# Altium schematic sheet AnalogEnvelopePath.SchDoc(AnalogEnvelopePath)
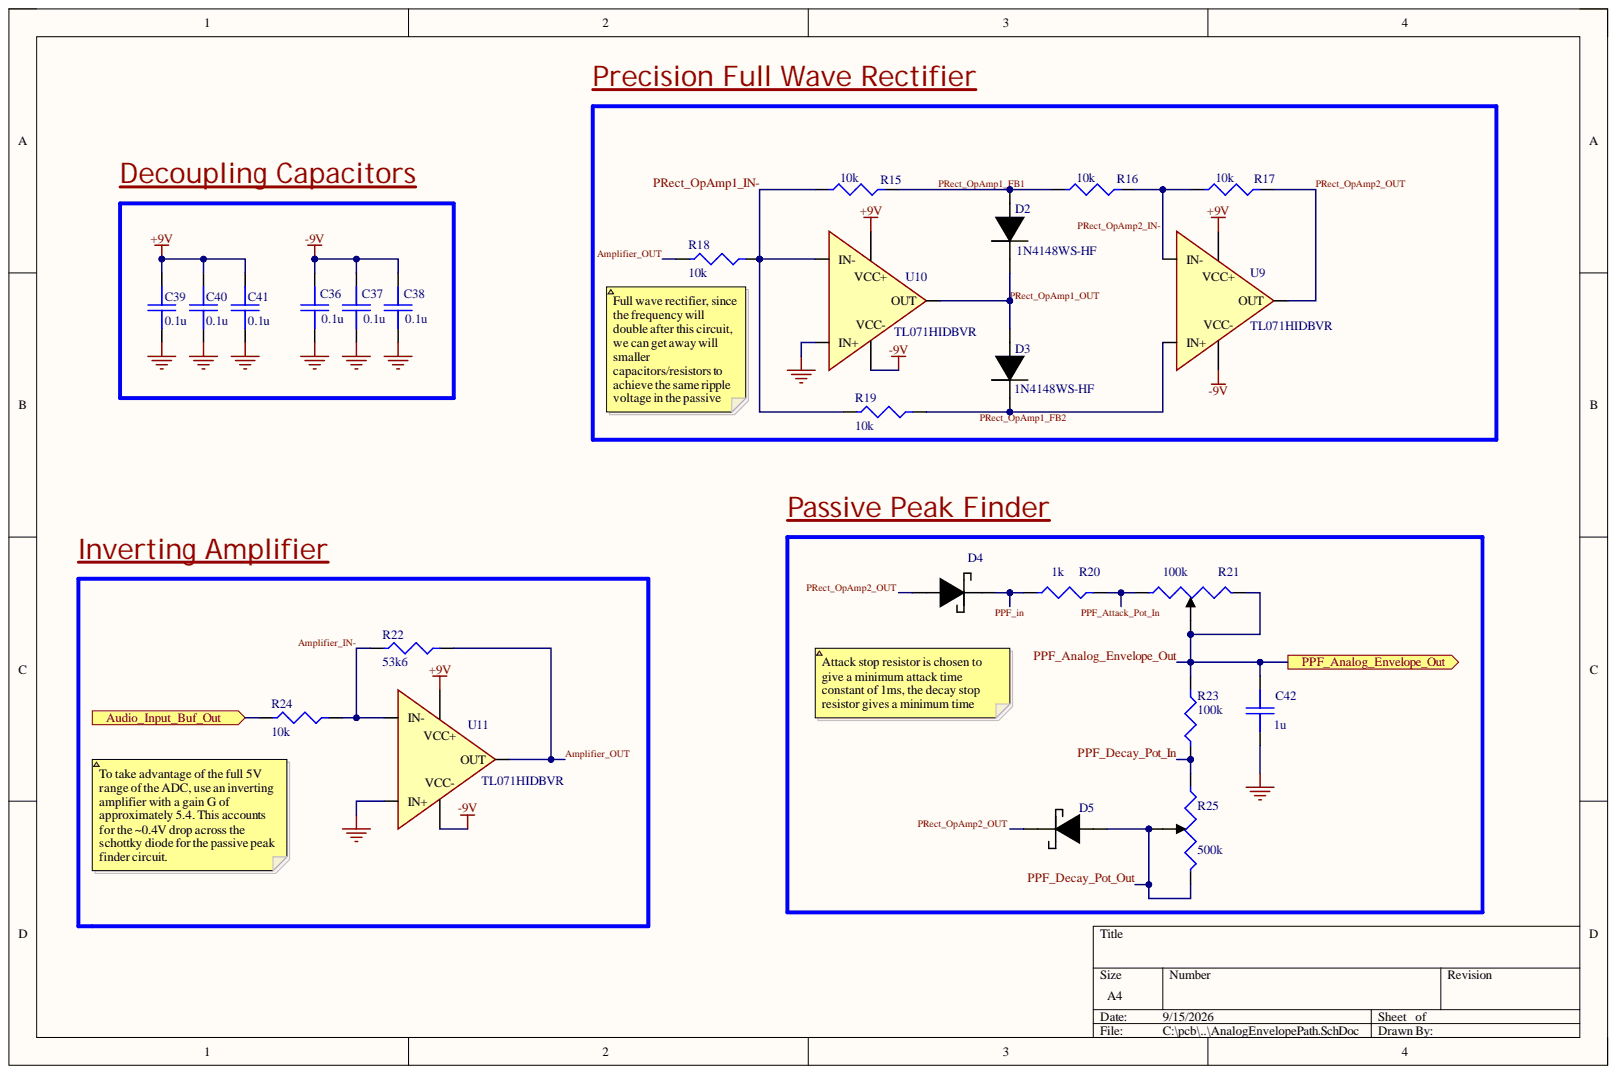
[diagram p0: AnalogEnvelopePath.SchDoc]

## Parts
C36  0.1u  [C0603]
C37  0.1u  [C0603]
C38  0.1u  [C0603]
C39  0.1u  [C0603]
C40  0.1u  [C0603]
C41  0.1u  [C0603]
C42  1u  [B32529D1105J289]
D2  1N4148WS-HF  [1N4148WS-HF]
D3  1N4148WS-HF  [1N4148WS-HF]
D4  CMOD6263 TR PBFREE  [CMOD6263]  (value not drawn)
D5  CMOD6263 TR PBFREE  [CMOD6263]  (value not drawn)
R15  10k  [R0603]
R16  10k  [R0603]
R17  10k  [R0603]
R18  10k  [R0603]
R19  10k  [R0603]
R20  1k  [R0603]
R21  100k  [RD901F-40-15R1-B100K-00D]
R22  53k6  [R0603]
R23  100k  [R0603]
R24  10k  [R0603]
R25  500k  [RD901F-40-15R1-B500K]
U9  TL071HIDBVR  [TL071HIDBVR]
U10  TL071HIDBVR  [TL071HIDBVR]
U11  TL071HIDBVR  [TL071HIDBVR]

## Ports
Audio_Input_Buf_Out, PPF_Analog_Envelope_Out

## Nets
+9V: C39.1 C40.1 C41.1 U9.5 U10.5 U11.5
-9V: C36.2 C37.2 C38.2 U9.2 U10.2 U11.2
GND: C36.1 C37.1 C38.1 C39.2 C40.2 C41.2 C42.1 U10.3(IN+) U11.3(IN+)
Amplifier_IN-: R22.1 R24.2 U11.4(IN-)
Amplifier_OUT: R18.1 R22.2 U11.1(OUT)
Audio_Input_Buf_Out: R24.1 ; port Audio_Input_Buf_Out
PPF_Analog_Envelope_Out: C42.2 R21.2 R21.3 R23.2 ; port PPF_Analog_Envelope_Out
PPF_Attack_Pot_In: R20.2 R21.1
PPF_Decay_Pot_In: R23.1 R25.1
PPF_Decay_Pot_Out: D5.2 R25.2 R25.3
PPF_in: D4.1 R20.1
PRect_OpAmp1_FB1: D2.2 R15.2 R16.1
PRect_OpAmp1_FB2: D3.1 R19.2 U9.3(IN+)
PRect_OpAmp1_IN-: R15.1 R18.2 R19.1 U10.4(IN-)
PRect_OpAmp1_OUT: D2.1 D3.2 U10.1(OUT)
PRect_OpAmp2_IN-: R16.2 R17.1 U9.4(IN-)
PRect_OpAmp2_OUT: D4.2 D5.1 R17.2 U9.1(OUT)

## Library data of the parts
C36-C41 = C0603C104K5RACAUTO: Multilayer Ceramic Capacitors MLCC - SMD/SMT 50V 0.1uF X7R 0603 10% AEC-Q200; Manufacturer: Yageo Group; Dissipation Factor: 2.5%; Temperature Coefficient: 30ppm/°C; Value: 100nF; Case Code (Imperial): 0603; Case Code (Metric): 1608; Case/Package: 0603; Category: Ceramic Capacitors; China RoHS: Compliant; Component Kind: Standard; ComponentLink1Description: https://datasheet.octopart.com:443/C0603C104K5RACAUTO-KEMET-datasheet-178087915.pdf?src-supplier=Farnell; ComponentLink1URL: https://datasheet.octopart.com:443/C0603C104K5RACAUTO-KEMET-datasheet-178087915.pdf?src-supplier=Farnell; ComponentLink2Description: https://datasheet.octopart.com:443/C0603C104K5RACAUTO-KEMET-datasheet-140480346.pdf?src-supplier=Farnell; ComponentLink2URL: https://datasheet.octopart.com:443/C0603C104K5RACAUTO-KEMET-datasheet-140480346.pdf?src-supplier=Farnell; ComponentLink3Description: https://datasheet.octopart.com:443/C0603C104K5RACAUTO-KEMET-datasheet-138896278.pdf?src-supplier=Farnell; ComponentLink3URL: https://datasheet.octopart.com:443/C0603C104K5RACAUTO-KEMET-datasheet-138896278.pdf?src-supplier=Farnell; ComponentLink4Description: https://datasheet.octopart.com:443/C0603C104K5RACAUTO-KEMET-datasheet-101575653.pdf?src-supplier=Farnell; ComponentLink4URL: https://datasheet.octopart.com:443/C0603C104K5RACAUTO-KEMET-datasheet-101575653.pdf?src-supplier=Farnell; ComponentLink5Description: https://datasheet.octopart.com:443/C0603C104K5RACAUTO-KEMET-datasheet-169773475.pdf?src-supplier=Farnell; ComponentLink5URL: https://datasheet.octopart.com:443/C0603C104K5RACAUTO-KEMET-datasheet-169773475.pdf?src-supplier=Farnell; ComponentLink6Description: https://datasheet.octopart.com:443/C0603C104K5RAC-AUTO-Kemet-datasheet-11517047.pdf?src-supplier=Farnell; ComponentLink6URL: https://datasheet.octopart.com:443/C0603C104K5RAC-AUTO-Kemet-datasheet-11517047.pdf?src-supplier=Farnell; ComponentLink7Description: https://datasheet.octopart.com:443/C0603C104K5RACAUTO-KEMET-datasheet-137357764.pdf?src-supplier=Upverter; ComponentLink7URL: https://datasheet.octopart.com:443/C0603C104K5RACAUTO-KEMET-datasheet-137357764.pdf?src-supplier=Upverter; ComponentLink8Description: https://datasheet.octopart.com:443/C0603C104K5RACAUTO-Kemet-datasheet-17277841.pdf?src-supplier=Newark; ComponentLink8URL: https://datasheet.octopart.com:443/C0603C104K5RACAUTO-Kemet-datasheet-17277841.pdf?src-supplier=Newark; ComponentLink9Description: https://datasheet.octopart.com:443/C0603C104K5RAC-AUTO-Kemet-datasheet-10850653.pdf?src-supplier=Newark; ComponentLink9URL: https://datasheet.octopart.com:443/C0603C104K5RAC-AUTO-Kemet-datasheet-10850653.pdf?src-supplier=Newark; ComponentLink10Description: https://datasheet.octopart.com:443/C0603C104K5RACAUTO-KEMET-datasheet-176289396.pdf?src-supplier=JRH+Electronics; ComponentLink10URL: https://datasheet.octopart.com:443/C0603C104K5RACAUTO-KEMET-datasheet-176289396.pdf?src-supplier=JRH+Electronics; ComponentLink11Description: https://datasheet.octopart.com:443/C0603C104K5RACAUTO-KEMET-datasheet-153410967.pdf?src-supplier=Electro+Sonic; ComponentLink11URL: https://datasheet.octopart.com:443/C0603C104K5RACAUTO-KEMET-datasheet-153410967.pdf?src-supplier=Electro+Sonic; ComponentLink12Description: https://datasheet.octopart.com:443/C0603C104K5RACAUTO-KEMET-datasheet-142166320.pdf?src-supplier=Electro+Sonic; ComponentLink12URL: https://datasheet.octopart.com:443/C0603C104K5RACAUTO-KEMET-datasheet-142166320.pdf?src-supplier=Electro+Sonic; ComponentLink13Description: https://datasheet.octopart.com:443/C0603C104K5RACAUTO-Kemet-datasheet-66076775.pdf?src-supplier=element14+APAC; ComponentLink13URL: https://datasheet.octopart.com:443/C0603C104K5RACAUTO-Kemet-datasheet-66076775.pdf?src-supplier=element14+APAC; ComponentLink14Description: https://datasheet.octopart.com:443/C0603C104K5RACAUTO-Kemet-datasheet-66204749.pdf?src-supplier=element14+APAC; ComponentLink14URL: https://datasheet.octopart.com:443/C0603C104K5RACAUTO-Kemet-datasheet-66204749.pdf?src-supplier=element14+APAC; ComponentLink15Description: https://datasheet.octopart.com:443/C0603C104K5RACAUTO-Kemet-datasheet-66534724.pdf?src-supplier=element14+APAC; ComponentLink15URL: https://datasheet.octopart.com:443/C0603C104K5RACAUTO-Kemet-datasheet-66534724.pdf?src-supplier=element14+APAC; ComponentLink16Description: https://datasheet.octopart.com:443/C0603C104K5RACAUTO-KEMET-datasheet-83968784.pdf?src-supplier=TME; ComponentLink16URL: https://datasheet.octopart.com:443/C0603C104K5RACAUTO-KEMET-datasheet-83968784.pdf?src-supplier=TME; ComponentLink17Description: https://datasheet.octopart.com:443/C0603C104K5RACAUTO-KEMET-datasheet-83186888.pdf?src-supplier=TME; ComponentLink17URL: https://datasheet.octopart.com:443/C0603C104K5RACAUTO-KEMET-datasheet-83186888.pdf?src-supplier=TME; ComponentLink18Description: https://datasheet.octopart.com/C0603C104K5RACAUTO-KEMET-datasheet-84169754.pdf; ComponentLink18URL: https://datasheet.octopart.com/C0603C104K5RACAUTO-KEMET-datasheet-84169754.pdf; ComponentLink19Description: https://datasheet.octopart.com:443/C0603C104K5RACAUTO-KEMET-datasheet-189767472.pdf?src-supplier=ODG+(Origin+Data+Global); ComponentLink19URL: https://datasheet.octopart.com:443/C0603C104K5RACAUTO-KEMET-datasheet-189767472.pdf?src-supplier=ODG+(Origin+Data+Global); ComponentLink20Description: http://sigma.octopart.com:80/conflict_mineral_statement/Yageo-company-3.pdf?src-supplier=Compliance+Statements; ComponentLink20URL: http://sigma.octopart.com:80/conflict_mineral_statement/Yageo-company-3.pdf?src-supplier=Compliance+Statements; ComponentLink21Description: http://sigma.octopart.com:80/rohs_statement/Yageo-company-2.pdf?src-supplier=Compliance+Statements; ComponentLink21URL: http://sigma.octopart.com:80/rohs_statement/Yageo-company-2.pdf?src-supplier=Compliance+Statements; Contact Plating: Tin; Country of Origin: Mexico; Depth: 800µm; Dielectric: X7R; Dielectric Material: Ceramic; Export Control Classification Number (ECCN) Code: EAR99; Harmonized Tariff Schedule (HTS) Code: 8532.24.00.20; Height: 800µm; Introduction Date: 2011-07-27; Length: 1.6mm; Lifecycle Status: Production; Manufacturer Part Number: C0603C104K5RACAUTO; Material: Ceramic; Max Operating Temperature: 125°C; Min Operating Temperature: -55°C; Mounting Technology: Surface Mount; Number of Terminals: 2; Packaging: Cut Tape; Pricing: 1=0.15, 10=0.083, 50=0.0574, 100=0.0497, 500=0.0367 (USD); Radiation Hardening: No; Ratings: AEC-Q200; REACH SVHC: Yes; RoHS: Compliant; Schedule B: 8532240020, 8532240020|8532240020, 8532240020|8532240020|8532240020, 8532240020|8532240020|8532240020|8532240020; Stock: 1408607; Supplier: DigiKey; Supplier Part Number: 399-6856-6-ND; Termination: SMD/SMT; Thickness: 863.6µm; Tolerance: 10%; Voltage Rating: 50V; Voltage Rating (DC): 50V; Width: 800µm; Library Name: Tremelo_Pedal.SchLib
C42 = B32529D1105J289: Cap Film 1uF 100V PET 5% (7.8 X 7.8 X 13mm) Radial Stacked 5mm 125C Automotive Ammo; Manufacturer: TDK; Manufacturer Lifecycle Status: PRODUCTION; Lead Diameter: 500µm; Value: 1µF; Voltage Rating (AC): 63V; Case/Package: Radial; Category: Film Capacitors; China RoHS: Compliant; Component Kind: Standard; ComponentLink1Description: https://datasheet.octopart.com:443/B32529D1105J289-TDK-datasheet-154543793.pdf?src-supplier=TDK; ComponentLink1URL: https://datasheet.octopart.com:443/B32529D1105J289-TDK-datasheet-154543793.pdf?src-supplier=TDK; ComponentLink2Description: https://datasheet.octopart.com:443/B32529D1105J289-EPCOS-datasheet-44890114.pdf?src-supplier=Newark; ComponentLink2URL: https://datasheet.octopart.com:443/B32529D1105J289-EPCOS-datasheet-44890114.pdf?src-supplier=Newark; ComponentLink3Description: https://datasheet.octopart.com:443/B32529D1105J289-TDK-datasheet-136998817.pdf?src-supplier=Ciiva; ComponentLink3URL: https://datasheet.octopart.com:443/B32529D1105J289-TDK-datasheet-136998817.pdf?src-supplier=Ciiva; ComponentLink4Description: https://datasheet.octopart.com:443/B32529D1105J289-TDK-datasheet-128073551.pdf?src-supplier=TME; ComponentLink4URL: https://datasheet.octopart.com:443/B32529D1105J289-TDK-datasheet-128073551.pdf?src-supplier=TME; ComponentLink5Description: https://datasheet.octopart.com:443/B32529D1105J289-EPCOS-datasheet-10542445.pdf?src-supplier=_legacy+Avnet; ComponentLink5URL: https://datasheet.octopart.com:443/B32529D1105J289-EPCOS-datasheet-10542445.pdf?src-supplier=_legacy+Avnet; ComponentLink6Description: https://datasheet.octopart.com:443/B32529D1105J289-EPCOS-datasheet-8329156.pdf?src-supplier=_legacy+Avnet; ComponentLink6URL: https://datasheet.octopart.com:443/B32529D1105J289-EPCOS-datasheet-8329156.pdf?src-supplier=_legacy+Avnet; Contact Plating: Tin; Depth: 7.8mm; Dielectric: PET; Dielectric Material: PET; Export Control Classification Number (ECCN) Code: EAR99; Harmonized Tariff Schedule (HTS) Code: 8532.25.00.70; Height: 13mm; Height - Seated (Max): 13.0048mm; Introduction Date: 2001-04-05; Lead Pitch: 5mm; Length: 7.8mm; Lifecycle Status: Production; Manufacturer Part Number: B32529D1105J289; Max Length: 7.8mm; Max Operating Temperature: 125°C; Max Width: 7.8mm; Min Operating Temperature: -55°C; Mounting Technology: Through Hole; Number of Terminals: 2; Packaging: Cut Tape (CT); Pricing: 1000=0.55007, 2000=0.52392, 3000=0.51082, 5000=0.4963, 7000=0.48781, 10000=0.47964 (USD); Ratings: AEC-Q200; REACH SVHC: Yes; RoHS: Compliant; Schedule B: 8532250010; Stock: 31000; Supplier: DigiKey; Supplier Part Number: 495-4875-3-ND; Terminal Pitch: 5mm; Termination: Radial; Tolerance: 5%; Voltage Rating (DC): 100V; Width: 7.8mm; Library Name: Tremelo_Pedal.SchLib
D2 D3 = 1N4148WS-HF: Rectifier Diode, 1 Phase, 1 Element, 0.15A, 100V V(RRM), Silicon; Manufacturer: Comchip; Forward Voltage: 1.25V; Max Forward Surge Current (Ifsm): 2A; Max Output current: 150mA; Max Power Dissipation: 200mW; Min Breakdown Voltage: 75V; Number of Elements: 1; Peak Reverse Current: 1µA; Reverse Recovery Time: 4ns; Category: Rectifier Diodes; Component Kind: Standard; ComponentLink1Description: https://datasheet.octopart.com:443/1N4148WS-HF-Comchip-datasheet-180123836.pdf?src-supplier=IHS; ComponentLink1URL: https://datasheet.octopart.com:443/1N4148WS-HF-Comchip-datasheet-180123836.pdf?src-supplier=IHS; ComponentLink2Description: https://datasheet.octopart.com:443/1N4148WS-HF-Comchip-datasheet-194169543.pdf?src-supplier=LCSC; ComponentLink2URL: https://datasheet.octopart.com:443/1N4148WS-HF-Comchip-datasheet-194169543.pdf?src-supplier=LCSC; Country of Origin: Taiwan; Export Control Classification Number (ECCN) Code: EAR99; Harmonized Tariff Schedule (HTS) Code: 8541.10.00.70; Introduction Date: 2019-09-12; Lifecycle Status: Production; Manufacturer Part Number: 1N4148WS-HF; Max Operating Temperature: 125°C; Number of Terminals: 2; Pricing: 1=0.17, 10=0.107, 50=0.076, 100=0.0658, 500=0.04768, 3000=0.03427, 9000=0.02851 (USD); REACH SVHC: Yes; Reverse Standoff Voltage: 75V; RoHS: Compliant; Stock: 298119; Supplier: DigiKey; Supplier Part Number: 641-1N4148WS-HFCT-ND; Library Name: Tremelo_Pedal.SchLib
D4 D5 = CMOD6263 TR PBFREE: Diode Small Signal Schottky 70V 15mA 2-Pin SOD-523 T/R; Manufacturer: Central Semiconductor; Category: Rectifier Diodes; Component Kind: Standard; ComponentLink1Description: http://sigma.octopart.com:80/rohs_statement/Central-Semiconductor-company-43.pdf?src-supplier=Compliance+Statements; ComponentLink1URL: http://sigma.octopart.com:80/rohs_statement/Central-Semiconductor-company-43.pdf?src-supplier=Compliance+Statements; ComponentLink2Description: http://sigma.octopart.com:80/reach_statement/Central-Semiconductor-company-41.pdf?src-supplier=Compliance+Statements; ComponentLink2URL: http://sigma.octopart.com:80/reach_statement/Central-Semiconductor-company-41.pdf?src-supplier=Compliance+Statements; Manufacturer Part Number: CMOD6263 TR PBFREE; Pricing: 3000=0.18487, 9000=0.16136 (USD); RoHS: Compliant; Schedule B: 8541100040; Stock: 14014; Supplier: DigiKey; Supplier Part Number: 1514-CMOD6263TRPBFREETR-ND; Library Name: Tremelo_Pedal.SchLib
R15-R19 R24 = RT0603DRD0710KL: 100mW Thin Film Resistor 75V ±0.5% ±25ppm/℃ 10kΩ 0603 Chip Resistor - Surface Mount ROHS; Manufacturer: Yageo Group; Temperature Coefficient: 25ppm/°C; Voltage Rating (DC): 50V; Case Code (Metric): 0603; Case/Package: 0603; Category: Chip SMD Resistors; China RoHS: Non-Compliant; Component Kind: Standard; ComponentLink1Description: https://datasheet.octopart.com:443/RT0603DRD0710KL-Yageo-datasheet-176402239.pdf?src-supplier=Future+Electronics; ComponentLink1URL: https://datasheet.octopart.com:443/RT0603DRD0710KL-Yageo-datasheet-176402239.pdf?src-supplier=Future+Electronics; ComponentLink2Description: https://datasheet.octopart.com:443/RT0603DRD0710KL-Yageo-datasheet-169971040.pdf?src-supplier=Future+Electronics; ComponentLink2URL: https://datasheet.octopart.com:443/RT0603DRD0710KL-Yageo-datasheet-169971040.pdf?src-supplier=Future+Electronics; ComponentLink3Description: https://datasheet.octopart.com:443/RT0603DRD0710KL-Yageo-datasheet-166250672.pdf?src-supplier=Future+Electronics; ComponentLink3URL: https://datasheet.octopart.com:443/RT0603DRD0710KL-Yageo-datasheet-166250672.pdf?src-supplier=Future+Electronics; ComponentLink4Description: https://datasheet.octopart.com:443/RT0603DRD0710KL-Yageo-datasheet-136673517.pdf?src-supplier=Future+Electronics; ComponentLink4URL: https://datasheet.octopart.com:443/RT0603DRD0710KL-Yageo-datasheet-136673517.pdf?src-supplier=Future+Electronics; ComponentLink5Description: https://datasheet.octopart.com:443/RT0603DRD0710KL-Yageo-datasheet-137700930.pdf?src-supplier=Future+Electronics; ComponentLink5URL: https://datasheet.octopart.com:443/RT0603DRD0710KL-Yageo-datasheet-137700930.pdf?src-supplier=Future+Electronics; ComponentLink6Description: https://datasheet.octopart.com:443/RT0603DRD0710KL-Yageo-datasheet-41589729.pdf?src-supplier=Future+Electronics; ComponentLink6URL: https://datasheet.octopart.com:443/RT0603DRD0710KL-Yageo-datasheet-41589729.pdf?src-supplier=Future+Electronics; ComponentLink7Description: https://datasheet.octopart.com:443/RT0603DRD0710KL-Yageo-datasheet-10915759.pdf?src-supplier=Future+Electronics; ComponentLink7URL: https://datasheet.octopart.com:443/RT0603DRD0710KL-Yageo-datasheet-10915759.pdf?src-supplier=Future+Electronics; ComponentLink8Description: https://datasheet.octopart.com:443/RT0603DRD0710KL-Yageo-datasheet-34924148.pdf?src-supplier=Future+Electronics; ComponentLink8URL: https://datasheet.octopart.com:443/RT0603DRD0710KL-Yageo-datasheet-34924148.pdf?src-supplier=Future+Electronics; ComponentLink9Description: https://datasheet.octopart.com:443/RT0603DRD0710KL-Yageo-datasheet-96443642.pdf?src-supplier=Upverter; ComponentLink9URL: https://datasheet.octopart.com:443/RT0603DRD0710KL-Yageo-datasheet-96443642.pdf?src-supplier=Upverter; ComponentLink10Description: https://datasheet.octopart.com:443/RT0603DRD0710KL-Yageo-datasheet-12594463.pdf?src-supplier=Upverter; ComponentLink10URL: https://datasheet.octopart.com:443/RT0603DRD0710KL-Yageo-datasheet-12594463.pdf?src-supplier=Upverter; ComponentLink11Description: https://datasheet.octopart.com:443/RT0603DRD0710KL-Yageo-Group-datasheet-188167888.pdf?src-supplier=TME; ComponentLink11URL: https://datasheet.octopart.com:443/RT0603DRD0710KL-Yageo-Group-datasheet-188167888.pdf?src-supplier=TME; ComponentLink12Description: https://datasheet.octopart.com:443/RT0603DRD0710KL-Yageo-datasheet-164439670.pdf?src-supplier=Newark; ComponentLink12URL: https://datasheet.octopart.com:443/RT0603DRD0710KL-Yageo-datasheet-164439670.pdf?src-supplier=Newark; ComponentLink13Description: https://datasheet.octopart.com:443/RT0603DRD0710KL-Yageo-Group-datasheet-141265893.pdf?src-supplier=ODG+(Origin+Data+Global); ComponentLink13URL: https://datasheet.octopart.com:443/RT0603DRD0710KL-Yageo-Group-datasheet-141265893.pdf?src-supplier=ODG+(Origin+Data+Global); ComponentLink14Description: https://datasheet.octopart.com/RT0603DRD0710KL-Yageo-datasheet-86813807.pdf; ComponentLink14URL: https://datasheet.octopart.com/RT0603DRD0710KL-Yageo-datasheet-86813807.pdf; ComponentLink15Description: http://sigma.octopart.com:80/conflict_mineral_statement/Yageo-company-3.pdf?src-supplier=Compliance+Statements; ComponentLink15URL: http://sigma.octopart.com:80/conflict_mineral_statement/Yageo-company-3.pdf?src-supplier=Compliance+Statements; ComponentLink16Description: http://sigma.octopart.com:80/rohs_statement/Yageo-company-2.pdf?src-supplier=Compliance+Statements; ComponentLink16URL: http://sigma.octopart.com:80/rohs_statement/Yageo-company-2.pdf?src-supplier=Compliance+Statements; Composition: Thin Film; Country of Origin: Mainland China, Taiwan; Depth: 800µm; Export Control Classification Number (ECCN) Code: EAR99; Harmonized Tariff Schedule (HTS) Code: 8533.21.00.30; Height: 450µm; Introduction Date: 2008-07-11; Lead Free: Lead Free; Length: 1.6mm; Lifecycle Status: Production; Manufacturer Part Number: RT0603DRD0710KL; Max Operating Temperature: 155°C; Military Standard: Not; Min Operating Temperature: -55°C; Mounting Technology: Surface Mount; Number of Terminals: 2; Pins: 2; Power Rating: 100mW; Pricing: 1=0.11, 10=0.039, 50=0.0344, 100=0.0324, 500=0.02844, 5000=0.02388, 15000=0.0222 (USD); REACH SVHC: Yes; RoHS: Compliant; Schedule B: 8533210045, 8533210045|8533210045|8533210045|8533210045|8533210045|8533210045|8533210045|8533210045|8533210045|8533210045|8533210045|8533210045|8533210045|8533210045|8533210045|8533210045|8533210045|8533210045|8533210045|8533210045|8533210045|8533210045|8533210045|85; Stock: 838906; Supplier: DigiKey; Supplier Part Number: 311-10KDCT-ND; Tolerance: 0.5%; Value: 10kΩ; Voltage Rating: 75V; Width: 800µm; Working Voltage: 75V; Library Name: Tremelo_Pedal.SchLib
R20 = CR0603-JW-102ELF: Res Thick Film 0603 1K Ohm 5% 1/10W ±200ppm/°C Molded SMD SMD Paper Tape on Plastic Reel; Manufacturer: Bourns; Max Power Dissipation: 100mW; Value: 1kΩ; Voltage Rating: 75V; Voltage Rating (DC): 50V; Case Code (Imperial): 0603; Case Code (Metric): 1608; Case/Package: 0603; Category: Chip SMD Resistors; China RoHS: Non-Compliant; Component Kind: Standard; ComponentLink1Description: https://datasheet.octopart.com:443/CR0603-JW-102ELF-Bourns-datasheet-159578630.pdf?src-supplier=ODG+(Origin+Data+Global); ComponentLink1URL: https://datasheet.octopart.com:443/CR0603-JW-102ELF-Bourns-datasheet-159578630.pdf?src-supplier=ODG+(Origin+Data+Global); ComponentLink2Description: https://datasheet.octopart.com:443/CR0603-JW-102ELF-Bourns-datasheet-181955239.pdf?src-supplier=IHS; ComponentLink2URL: https://datasheet.octopart.com:443/CR0603-JW-102ELF-Bourns-datasheet-181955239.pdf?src-supplier=IHS; ComponentLink3Description: https://datasheet.octopart.com:443/CR0603-JW-102ELF-Bourns-datasheet-68301634.pdf?src-supplier=element14+APAC; ComponentLink3URL: https://datasheet.octopart.com:443/CR0603-JW-102ELF-Bourns-datasheet-68301634.pdf?src-supplier=element14+APAC; ComponentLink4Description: https://datasheet.octopart.com:443/CR0603-JW-102ELF-Bourns-datasheet-34229249.pdf?src-supplier=element14+APAC; ComponentLink4URL: https://datasheet.octopart.com:443/CR0603-JW-102ELF-Bourns-datasheet-34229249.pdf?src-supplier=element14+APAC; ComponentLink5Description: https://datasheet.octopart.com/CR0603-JW-102ELF-Bourns-datasheet-5318152.pdf; ComponentLink5URL: https://datasheet.octopart.com/CR0603-JW-102ELF-Bourns-datasheet-5318152.pdf; ComponentLink6Description: https://datasheet.octopart.com:443/CR0603-JW-102ELF-Bourns-datasheet-154729663.pdf?src-supplier=Electro+Sonic; ComponentLink6URL: https://datasheet.octopart.com:443/CR0603-JW-102ELF-Bourns-datasheet-154729663.pdf?src-supplier=Electro+Sonic; ComponentLink7Description: https://datasheet.octopart.com:443/CR0603-JW-102ELF-Bourns-datasheet-11547086.pdf?src-supplier=Electro+Sonic; ComponentLink7URL: https://datasheet.octopart.com:443/CR0603-JW-102ELF-Bourns-datasheet-11547086.pdf?src-supplier=Electro+Sonic; ComponentLink8Description: https://datasheet.octopart.com:443/CR0603-JW-102ELF-Bourns-datasheet-49799764.pdf?src-supplier=Farnell; ComponentLink8URL: https://datasheet.octopart.com:443/CR0603-JW-102ELF-Bourns-datasheet-49799764.pdf?src-supplier=Farnell; ComponentLink9Description: https://datasheet.octopart.com:443/CR0603-JW-102ELF-Bourns-datasheet-24895818.pdf?src-supplier=Farnell; ComponentLink9URL: https://datasheet.octopart.com:443/CR0603-JW-102ELF-Bourns-datasheet-24895818.pdf?src-supplier=Farnell; ComponentLink10Description: https://datasheet.octopart.com:443/CR0603-JW-102ELF-Bourns-datasheet-22971629.pdf?src-supplier=Farnell; ComponentLink10URL: https://datasheet.octopart.com:443/CR0603-JW-102ELF-Bourns-datasheet-22971629.pdf?src-supplier=Farnell; ComponentLink11Description: https://datasheet.octopart.com:443/CR0603-JW-102ELF.-Bourns-datasheet-62114779.pdf?src-supplier=Farnell; ComponentLink11URL: https://datasheet.octopart.com:443/CR0603-JW-102ELF.-Bourns-datasheet-62114779.pdf?src-supplier=Farnell; ComponentLink12Description: https://datasheet.octopart.com:443/CR0603-JW-102ELF.-Bourns-datasheet-45190937.pdf?src-supplier=Farnell; ComponentLink12URL: https://datasheet.octopart.com:443/CR0603-JW-102ELF.-Bourns-datasheet-45190937.pdf?src-supplier=Farnell; ComponentLink13Description: https://datasheet.octopart.com:443/CR0603-JW-102ELF-Bourns-datasheet-140567134.pdf?src-supplier=Arrow.cn; ComponentLink13URL: https://datasheet.octopart.com:443/CR0603-JW-102ELF-Bourns-datasheet-140567134.pdf?src-supplier=Arrow.cn; ComponentLink14Description: https://datasheet.octopart.com:443/CR0603-JW-102ELF-Bourns-datasheet-66078858.pdf?src-supplier=Jameco+(USA); ComponentLink14URL: https://datasheet.octopart.com:443/CR0603-JW-102ELF-Bourns-datasheet-66078858.pdf?src-supplier=Jameco+(USA); ComponentLink15Description: http://sigma.octopart.com:80/conflict_mineral_statement/Bourns-company-36.pdf?src-supplier=Compliance+Statements; ComponentLink15URL: http://sigma.octopart.com:80/conflict_mineral_statement/Bourns-company-36.pdf?src-supplier=Compliance+Statements; Composition: Thick Film; Contact Plating: Lead, Tin; Country of Origin: Taiwan; Depth: 800µm; Export Control Classification Number (ECCN) Code: EAR99; Features: Moisture Resistant; Harmonized Tariff Schedule (HTS) Code: 8533.21.00.30; Height: 450µm; Introduction Date: 2003-06-20; Length: 1.6mm; Lifecycle Status: Production; Manufacturer Part Number: CR0603-JW-102ELF; Max Operating Temperature: 155°C; Military Standard: Not; Min Operating Temperature: -55°C; Mounting Technology: Surface Mount; Number of Terminals: 2; Number of Terminations: 2; Packaging: Cut Tape; Pins: 2; Power Rating: 100mW; Pricing: 1=0.1, 10=0.02, 25=0.0144, 50=0.0114, 100=0.0091, 250=0.00692, 500=0.0057, 1000=0.00475 (USD); Radiation Hardening: No; REACH SVHC: Yes; RoHS: Compliant; Schedule B: 8533210025, 8533210045, 8533210045|8533210045, 8533210045|8533210045|8533210045; Stock: 1020641; Supplier: DigiKey; Supplier Part Number: CR0603-JW-102ELFDKR-ND; Temperature Coefficient: 200ppm/°C; Termination: SMD/SMT; Tolerance: 5%; Width: 800µm; Working Voltage: 75V; Library Name: Tremelo_Pedal.SchLib
R21 = RD901F-40-15R1-B100K-00D: 100K OHM Linear Taper Potentiometer Round Shaft PCB 9mm.; Component Kind: Standard; ComponentLink1Description: Distributor; ComponentLink1URL: https://www.taydaelectronics.com/100k-ohm-linear-taper-potentiometer-round-shaft-pcb-9mm.html; ComponentLink2Description: New link; Library Name: Tremelo_Pedal.SchLib
R22 = TNPW060353K6BEEA: Fixed Resistor, Thin Film, 0.1W, 53600ohm, 75V, 0.1% +/-Tol, 25ppm/Cel, Surface Mount, 0603; Manufacturer: Vishay; Max Power Dissipation: 100mW; Temperature Coefficient: 25ppm/°C; Value: 53.6kΩ; Voltage Rating (DC): 50V; Case Code (Imperial): 0603; Case Code (Metric): 1608; Case/Package: 0603; Category: Chip SMD Resistors; China RoHS: Compliant; Component Kind: Standard; ComponentLink1Description: https://datasheet.octopart.com:443/TNPW060353K6BEEA-Vishay-datasheet-182188515.pdf?src-supplier=IHS; ComponentLink1URL: https://datasheet.octopart.com:443/TNPW060353K6BEEA-Vishay-datasheet-182188515.pdf?src-supplier=IHS; ComponentLink2Description: https://datasheet.octopart.com:443/TNPW060353K6BEEA-Vishay-Dale-datasheet-182026744.pdf?src-supplier=IHS; ComponentLink2URL: https://datasheet.octopart.com:443/TNPW060353K6BEEA-Vishay-Dale-datasheet-182026744.pdf?src-supplier=IHS; ComponentLink3Description: https://datasheet.octopart.com/TNPW060353K6BEEA-Vishay-datasheet-13498837.pdf; ComponentLink3URL: https://datasheet.octopart.com/TNPW060353K6BEEA-Vishay-datasheet-13498837.pdf; ComponentLink4Description: https://datasheet.octopart.com/TNPW060353K6BEEA-Vishay-datasheet-13414878.pdf; ComponentLink4URL: https://datasheet.octopart.com/TNPW060353K6BEEA-Vishay-datasheet-13414878.pdf; ComponentLink5Description: https://datasheet.octopart.com/TNPW060353K6BEEA-Vishay-datasheet-13295955.pdf; ComponentLink5URL: https://datasheet.octopart.com/TNPW060353K6BEEA-Vishay-datasheet-13295955.pdf; ComponentLink6Description: https://datasheet.octopart.com/TNPW060353K6BEEA-Vishay-datasheet-13196373.pdf; ComponentLink6URL: https://datasheet.octopart.com/TNPW060353K6BEEA-Vishay-datasheet-13196373.pdf; ComponentLink7Description: https://datasheet.octopart.com/TNPW060353K6BEEA-Vishay-datasheet-13143890.pdf; ComponentLink7URL: https://datasheet.octopart.com/TNPW060353K6BEEA-Vishay-datasheet-13143890.pdf; ComponentLink8Description: https://datasheet.octopart.com/TNPW060353K6BEEA-Vishay-datasheet-13081780.pdf; ComponentLink8URL: https://datasheet.octopart.com/TNPW060353K6BEEA-Vishay-datasheet-13081780.pdf; ComponentLink9Description: https://datasheet.octopart.com/TNPW060353K6BEEA-Vishay-datasheet-13052401.pdf; ComponentLink9URL: https://datasheet.octopart.com/TNPW060353K6BEEA-Vishay-datasheet-13052401.pdf; ComponentLink10Description: https://datasheet.octopart.com/TNPW060353K6BEEA-Vishay-datasheet-13034137.pdf; ComponentLink10URL: https://datasheet.octopart.com/TNPW060353K6BEEA-Vishay-datasheet-13034137.pdf; ComponentLink11Description: https://datasheet.octopart.com/TNPW060353K6BEEA-Vishay-datasheet-12585086.pdf; ComponentLink11URL: https://datasheet.octopart.com/TNPW060353K6BEEA-Vishay-datasheet-12585086.pdf; ComponentLink12Description: https://datasheet.octopart.com/TNPW060353K6BEEA-Vishay-datasheet-12543131.pdf; ComponentLink12URL: https://datasheet.octopart.com/TNPW060353K6BEEA-Vishay-datasheet-12543131.pdf; ComponentLink13Description: https://datasheet.octopart.com/TNPW060353K6BEEA-Vishay-datasheet-12532511.pdf; ComponentLink13URL: https://datasheet.octopart.com/TNPW060353K6BEEA-Vishay-datasheet-12532511.pdf; ComponentLink14Description: https://datasheet.octopart.com/TNPW060353K6BEEA-Vishay-datasheet-12519971.pdf; ComponentLink14URL: https://datasheet.octopart.com/TNPW060353K6BEEA-Vishay-datasheet-12519971.pdf; ComponentLink15Description: https://datasheet.octopart.com/TNPW060353K6BEEA-Vishay-datasheet-12509767.pdf; ComponentLink15URL: https://datasheet.octopart.com/TNPW060353K6BEEA-Vishay-datasheet-12509767.pdf; ComponentLink16Description: https://datasheet.octopart.com/TNPW060353K6BEEA-Vishay-datasheet-12365287.pdf; ComponentLink16URL: https://datasheet.octopart.com/TNPW060353K6BEEA-Vishay-datasheet-12365287.pdf; ComponentLink17Description: https://datasheet.octopart.com/TNPW060353K6BEEA-Vishay-datasheet-10854096.pdf; ComponentLink17URL: https://datasheet.octopart.com/TNPW060353K6BEEA-Vishay-datasheet-10854096.pdf; ComponentLink18Description: https://datasheet.octopart.com/TNPW060353K6BEEA-Vishay-datasheet-10678752.pdf; ComponentLink18URL: https://datasheet.octopart.com/TNPW060353K6BEEA-Vishay-datasheet-10678752.pdf; ComponentLink19Description: https://datasheet.octopart.com/TNPW060353K6BEEA-Vishay-datasheet-10283461.pdf; ComponentLink19URL: https://datasheet.octopart.com/TNPW060353K6BEEA-Vishay-datasheet-10283461.pdf; ComponentLink20Description: https://datasheet.octopart.com/TNPW060353K6BEEA-Vishay-datasheet-10185418.pdf; ComponentLink20URL: https://datasheet.octopart.com/TNPW060353K6BEEA-Vishay-datasheet-10185418.pdf; ComponentLink21Description: https://datasheet.octopart.com/TNPW060353K6BEEA-Vishay-datasheet-10055244.pdf; ComponentLink21URL: https://datasheet.octopart.com/TNPW060353K6BEEA-Vishay-datasheet-10055244.pdf; ComponentLink22Description: https://datasheet.octopart.com:443/TNPW060353K6BEEA-Vishay-Dale-datasheet-80324199.pdf?src-supplier=New+Yorker+Electronics; ComponentLink22URL: https://datasheet.octopart.com:443/TNPW060353K6BEEA-Vishay-Dale-datasheet-80324199.pdf?src-supplier=New+Yorker+Electronics; ComponentLink23Description: https://datasheet.octopart.com:443/TNPW060353K6BEEA-Vishay-datasheet-79783896.pdf?src-supplier=Future+Electronics; ComponentLink23URL: https://datasheet.octopart.com:443/TNPW060353K6BEEA-Vishay-datasheet-79783896.pdf?src-supplier=Future+Electronics; ComponentLink24Description: http://sigma.octopart.com:80/rohs_statement/Vishay-company-15.pdf?src-supplier=Compliance+Statements; ComponentLink24URL: http://sigma.octopart.com:80/rohs_statement/Vishay-company-15.pdf?src-supplier=Compliance+Statements; Composition: Thin Film; Contact Plating: Tin; Country of Origin: Germany, Israel; Export Control Classification Number (ECCN) Code: EAR99; Features: Anti-Sulfur, Automotive AEC-Q200, Moisture Resistant; Harmonized Tariff Schedule (HTS) Code: 8533.21.00.30; Height: 450µm; Introduction Date: 2006-07-14; Length: 1.55mm; Lifecycle Status: Production; Manufacturer Part Number: TNPW060353K6BEEA; Max Operating Temperature: 125°C; Min Operating Temperature: -55°C; Mounting Technology: Surface Mount; Number of Terminals: 2; Number of Terminations: 2; Packaging: Tape & Reel; Power Rating: 100mW; Pricing: 1=0.26, 10=0.219, 50=0.1918, 100=0.1812, 500=0.15972 (USD); Radiation Hardening: No; Ratings: AEC-Q200; REACH SVHC: Yes; RoHS: Compliant; Stock: 4999; Supplier: DigiKey; Supplier Part Number: 541-TNPW060353K6BEEADKR-ND; Termination: SMD/SMT; Tolerance: 0.1%; Voltage Rating: 75V; Weight: 2.012816mg; Width: 850µm; Working Voltage: 75V; Library Name: Tremelo_Pedal.SchLib
R23 = MCT0603MD1003DP500: Fixed Resistor, Thin Film, 0.11W, 100000ohm, 75V, 0.5% +/-Tol, 25ppm/Cel, Surface Mount, 0603; Manufacturer: Vishay; Max Power Dissipation: 150mW; Power Rating: 110mW; Temperature Coefficient: 25ppm/°C; Value: 100kΩ; Case Code (Imperial): 0603; Case Code (Metric): 1608; Case/Package: 0603; Category: Chip SMD Resistors; China RoHS: Non-Compliant; Component Kind: Standard; ComponentLink1Description: https://datasheet.octopart.com:443/MCT0603MD1003DP500-Vishay-datasheet-182027964.pdf?src-supplier=IHS; ComponentLink1URL: https://datasheet.octopart.com:443/MCT0603MD1003DP500-Vishay-datasheet-182027964.pdf?src-supplier=IHS; ComponentLink2Description: https://datasheet.octopart.com:443/MCT0603MD1003DP500-Vishay-datasheet-114607041.pdf?src-supplier=ODG+(Origin+Data+Global); ComponentLink2URL: https://datasheet.octopart.com:443/MCT0603MD1003DP500-Vishay-datasheet-114607041.pdf?src-supplier=ODG+(Origin+Data+Global); ComponentLink3Description: https://datasheet.octopart.com:443/MCT0603MD1003DP500-Vishay-datasheet-181963140.pdf?src-supplier=Newark; ComponentLink3URL: https://datasheet.octopart.com:443/MCT0603MD1003DP500-Vishay-datasheet-181963140.pdf?src-supplier=Newark; ComponentLink4Description: https://datasheet.octopart.com:443/MCT0603MD1003DP500-Vishay-datasheet-62318330.pdf?src-supplier=element14+APAC; ComponentLink4URL: https://datasheet.octopart.com:443/MCT0603MD1003DP500-Vishay-datasheet-62318330.pdf?src-supplier=element14+APAC; ComponentLink5Description: https://datasheet.octopart.com:443/MCT0603MD1003DP500-Vishay-datasheet-8643000.pdf?src-supplier=element14+APAC; ComponentLink5URL: https://datasheet.octopart.com:443/MCT0603MD1003DP500-Vishay-datasheet-8643000.pdf?src-supplier=element14+APAC; ComponentLink6Description: https://datasheet.octopart.com:443/MCT0603MD1003DP500-Vishay-datasheet-141944504.pdf?src-supplier=Arrow.cn; ComponentLink6URL: https://datasheet.octopart.com:443/MCT0603MD1003DP500-Vishay-datasheet-141944504.pdf?src-supplier=Arrow.cn; ComponentLink7Description: https://datasheet.octopart.com:443/MCT0603MD1003DP500-Vishay-datasheet-140572128.pdf?src-supplier=Arrow.cn; ComponentLink7URL: https://datasheet.octopart.com:443/MCT0603MD1003DP500-Vishay-datasheet-140572128.pdf?src-supplier=Arrow.cn; ComponentLink8Description: http://sigma.octopart.com:80/rohs_statement/Vishay-company-15.pdf?src-supplier=Compliance+Statements; ComponentLink8URL: http://sigma.octopart.com:80/rohs_statement/Vishay-company-15.pdf?src-supplier=Compliance+Statements; Composition: Thin Film; Depth: 850µm; Features: Anti-Sulfur, Automotive AEC-Q200; Height: 450µm; Length: 1.55mm; Lifecycle Status: Production; Manufacturer Part Number: MCT0603MD1003DP500; Max Operating Temperature: 125°C; Military Standard: Not; Min Operating Temperature: -55°C; Mounting Technology: Surface Mount; Number of Terminals: 2; Number of Terminations: 2; Pins: 2; Pricing: 1=0.1, 10=0.084, 50=0.0738, 100=0.0696, 500=0.06114 (USD); Ratings: AEC-Q200; REACH SVHC: Yes; RoHS: Compliant; Schedule B: 8533210045, 8533210045|8533210045|8533210045|8533210045|8533210045; Stock: 22085; Supplier: DigiKey; Supplier Part Number: MCT0603-100K-MDCT-ND; Tolerance: 0.5%; Voltage Rating: 75V; Voltage Rating (DC): 75V; Width: 850µm; Working Voltage: 75V; Library Name: Tremelo_Pedal.SchLib
R25 = RD901F-40-15R1-B500K: 500K OHM Linear Taper Potentiometer Round Shaft PCB 9mm.; Component Kind: Standard; ComponentLink1Description: Distributor; ComponentLink1URL: https://www.taydaelectronics.com/500k-ohm-linear-taper-potentiometer-round-shaft-pcb-9mm.html; Library Name: Tremelo_Pedal.SchLib
U9-U11 = TL071HIDBVR: Single, 40-V, 5-MHz, 4-mV offset voltage, 20-V/µs, In to V+ op amp with -40°C to 125°C operation 5-SOT-23 -40 to 125; Manufacturer: Texas Instruments; Manufacturer Lifecycle Status: ACTIVE; Number of Channels: 1; Case/Package: SOT-23; Category: Amplifiers - Op Amps, Buffer, Instrumentation; Component Kind: Standard; ComponentLink1Description: https://sigma.octopart.com:443/177332311/technical_drawing/Texas-Instruments-TL071HIDBVR.pdf?src-supplier=Texas+Instruments; ComponentLink1URL: https://sigma.octopart.com:443/177332311/technical_drawing/Texas-Instruments-TL071HIDBVR.pdf?src-supplier=Texas+Instruments; ComponentLink2Description: https://octopart.com:443/opatz8j6/c1?t=NeYbaGdGpDtfp13XBVX-_BIREoTJ0JJL4-vxQ-0MnCY4H9YG30NxCGE6_JL9myIRaTxs_TDMvtb6gUEdJaVSuB2D_Vy915w2Kx3McrBcvpBy3VtpOGFIdAWCI7S8wjPY9GIThFqsTt4Qlmo34mDI744b8fbTvAWm5dHUgA50mTRWRptzvU0Y0LKLWxytkrpq1B0YEw0PjBiGCR_gVoreAsDTlSM-L9NTJH5rz8lWVrRij6wgpRte34-I3FlMeFHAuf3eL2xOEmHTlOEnwn_wRUwwPKomNcVroqc295muhSB74pMqiL0F49CddwZMltWnAuHOeMq8DjpYhVeSu-tyDG8Aeb4sxj0AR6XENBzWLh6jY_euby9Ykfdr_g0OUYOEyNHkYVM&src-supplier=Texas+Instruments; ComponentLink2URL: https://octopart.com:443/opatz8j6/c1?t=NeYbaGdGpDtfp13XBVX-_BIREoTJ0JJL4-vxQ-0MnCY4H9YG30NxCGE6_JL9myIRaTxs_TDMvtb6gUEdJaVSuB2D_Vy915w2Kx3McrBcvpBy3VtpOGFIdAWCI7S8wjPY9GIThFqsTt4Qlmo34mDI744b8fbTvAWm5dHUgA50mTRWRptzvU0Y0LKLWxytkrpq1B0YEw0PjBiGCR_gVoreAsDTlSM-L9NTJH5rz8lWVrRij6wgpRte34-I3FlMeFHAuf3eL2xOEmHTlOEnwn_wRUwwPKomNcVroqc295muhSB74pMqiL0F49CddwZMltWnAuHOeMq8DjpYhVeSu-tyDG8Aeb4sxj0AR6XENBzWLh6jY_euby9Ykfdr_g0OUYOEyNHkYVM&src-supplier=Texas+Instruments; ComponentLink3Description: https://sigma.octopart.com:443/175570406/technical_drawing/Texas-Instruments-TL071HIDBVR.pdf?src-supplier=Texas+Instruments; ComponentLink3URL: https://sigma.octopart.com:443/175570406/technical_drawing/Texas-Instruments-TL071HIDBVR.pdf?src-supplier=Texas+Instruments; ComponentLink4Description: https://sigma.octopart.com:443/169406034/technical_drawing/Texas-Instruments-TL071HIDBVR.pdf?src-supplier=Texas+Instruments; ComponentLink4URL: https://sigma.octopart.com:443/169406034/technical_drawing/Texas-Instruments-TL071HIDBVR.pdf?src-supplier=Texas+Instruments; ComponentLink5Description: https://sigma.octopart.com:443/155939316/technical_drawing/Texas-Instruments-TL071HIDBVR.pdf?src-supplier=Texas+Instruments; ComponentLink5URL: https://sigma.octopart.com:443/155939316/technical_drawing/Texas-Instruments-TL071HIDBVR.pdf?src-supplier=Texas+Instruments; ComponentLink6Description: http://sigma.octopart.com:80/reach_statement/Texas-Instruments-company-64.pdf?src-supplier=Compliance+Statements; ComponentLink6URL: http://sigma.octopart.com:80/reach_statement/Texas-Instruments-company-64.pdf?src-supplier=Compliance+Statements; ComponentLink7Description: http://sigma.octopart.com:80/conflict_mineral_statement/Texas-Instruments-company-63.pdf?src-supplier=Compliance+Statements; ComponentLink7URL: http://sigma.octopart.com:80/conflict_mineral_statement/Texas-Instruments-company-63.pdf?src-supplier=Compliance+Statements; Height: 1.45mm; Length: 2.9mm; Lifecycle Status: Production; Manufacturer Part Number: TL071HIDBVR; Pins: 5; Pricing: 1=0.29, 10=0.2, 25=0.1768, 100=0.1519, 250=0.13996, 500=0.13278, 1000=0.12684 (USD); RoHS: Compliant; Stock: 17939; Supplier: DigiKey; Supplier Part Number: 296-TL071HIDBVRCT-ND; Thickness: 1.2mm; Width: 1.6mm; Library Name: Tremelo_Pedal.SchLib
